# Altium schematic sheet MCU.SchDoc(MCU)
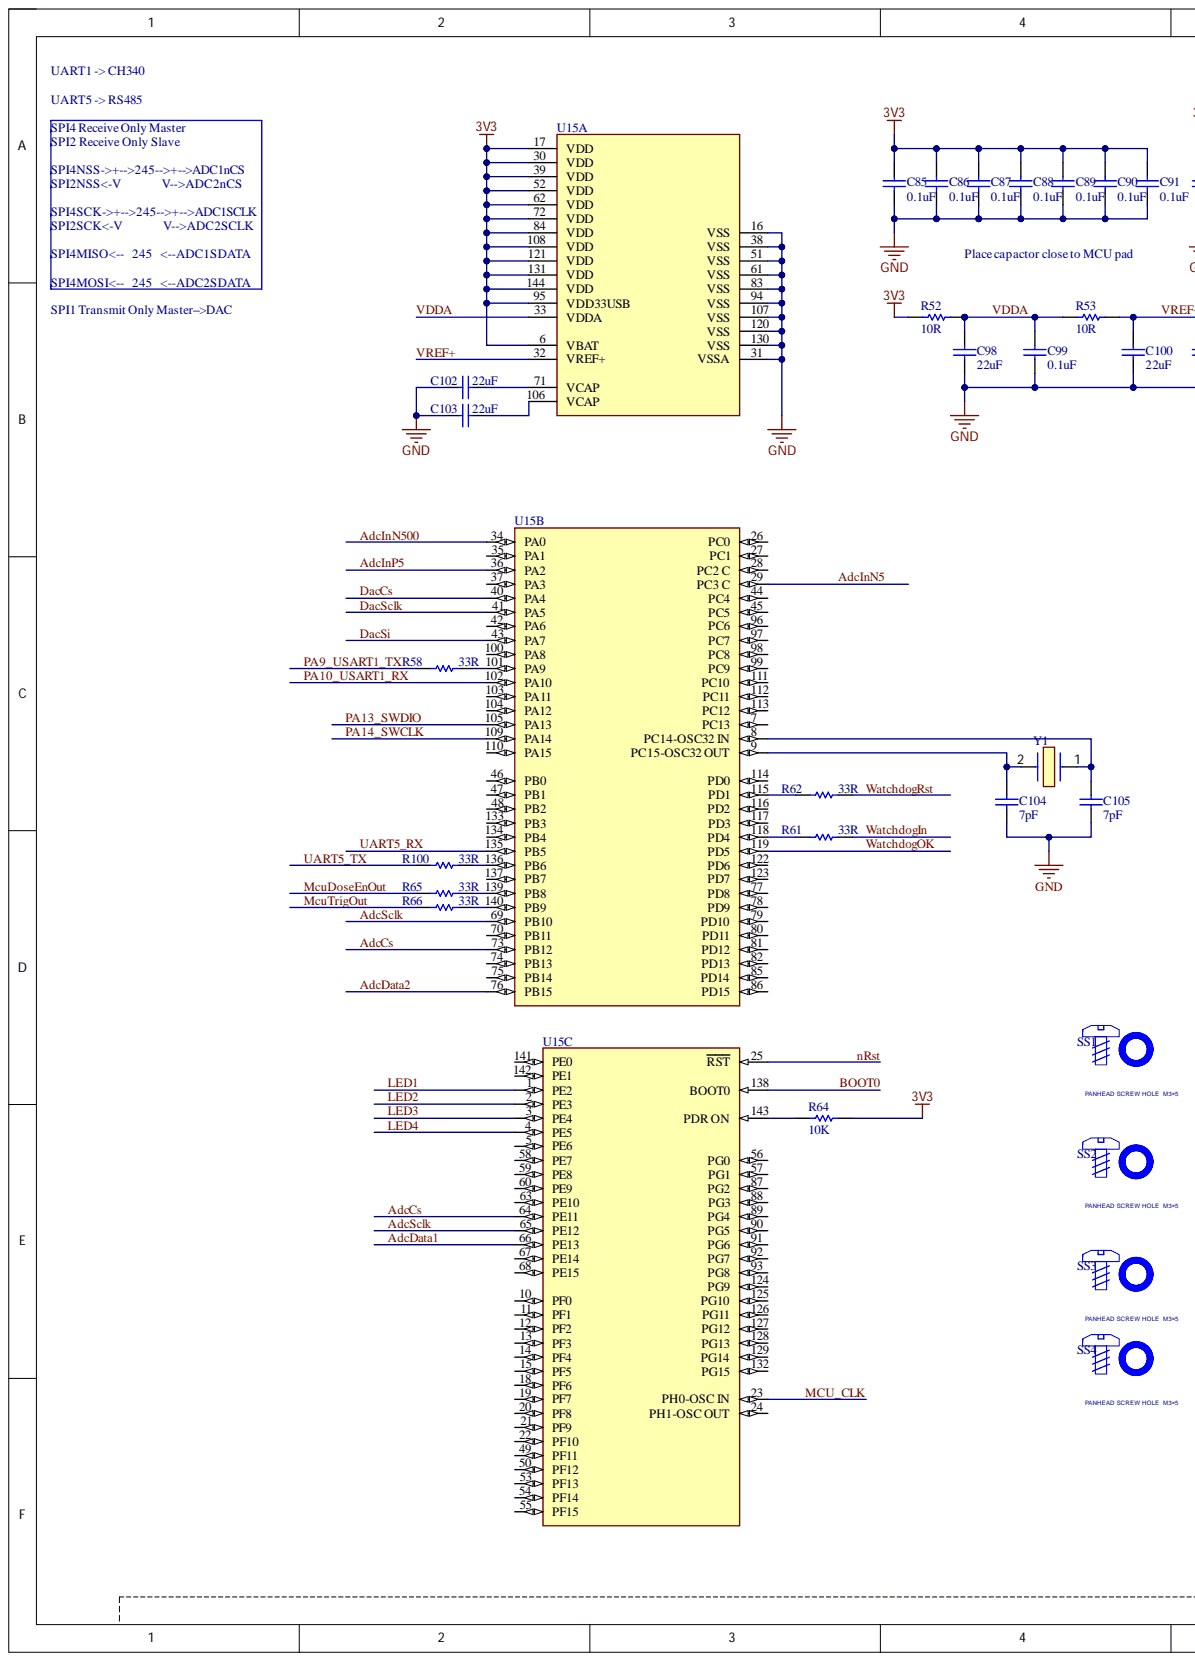
[diagram p0: MCU.SchDoc, left]
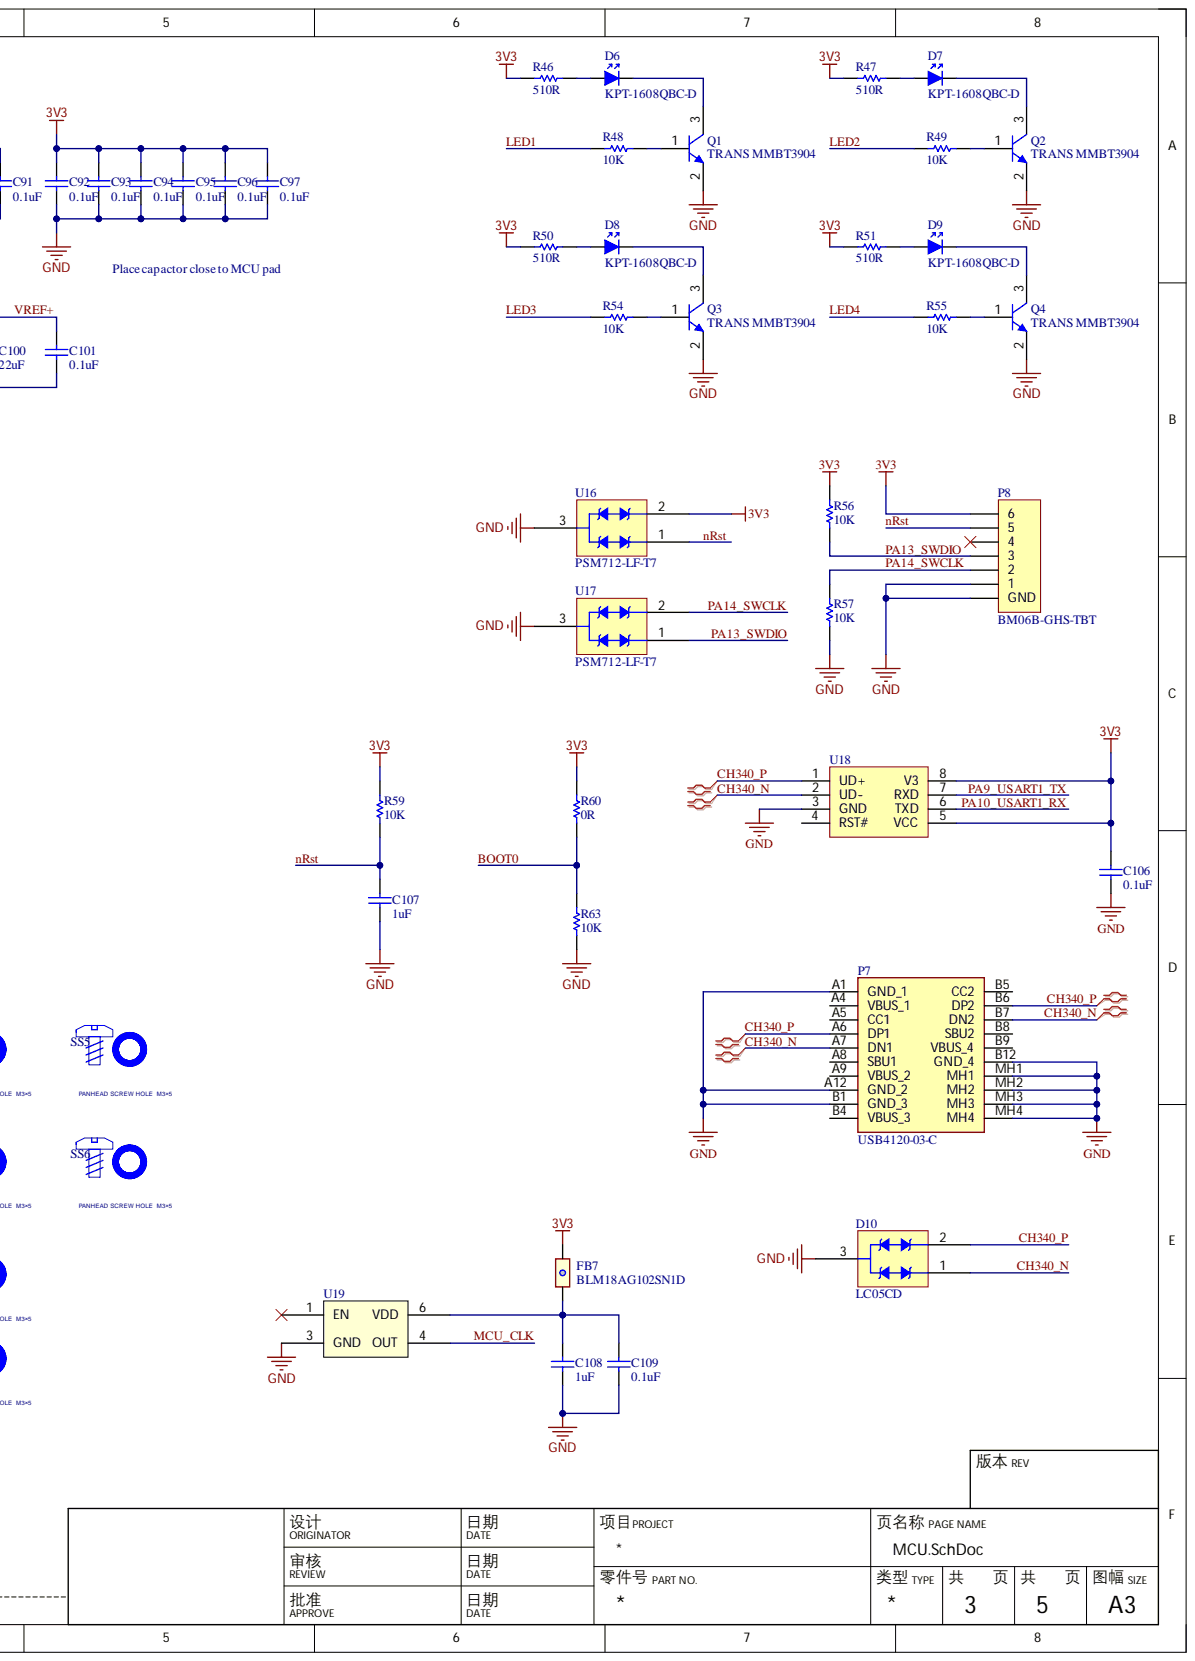
[diagram p1: MCU.SchDoc, right]

## Parts
C85  0.1uF  [C0402(1005)]
C86  0.1uF  [C0402(1005)]
C87  0.1uF  [C0402(1005)]
C88  0.1uF  [C0402(1005)]
C89  0.1uF  [C0402(1005)]
C90  0.1uF  [C0402(1005)]
C91  0.1uF  [C0402(1005)]
C92  0.1uF  [C0402(1005)]
C93  0.1uF  [C0402(1005)]
C94  0.1uF  [C0402(1005)]
C95  0.1uF  [C0402(1005)]
C96  0.1uF  [C0402(1005)]
C97  0.1uF  [C0402(1005)]
C98  22uF  [C0805(2012)]
C99  0.1uF  [C0402(1005)]
C100  22uF  [C0805(2012)]
C101  0.1uF  [C0402(1005)]
C102  22uF  [C0805(2012)]
C103  22uF  [C0805(2012)]
C104  7pF  [C0603(1608)]
C105  7pF  [C0603(1608)]
C106  0.1uF  [C0603(1608)]
C107  1uF  [C0402(1005)]
C108  1uF  [C0402(1005)]
C109  0.1uF  [C0402(1005)]
D6  KPT-1608QBC-D  [KPT-1608QBC-D]
D7  KPT-1608QBC-D  [KPT-1608QBC-D]
D8  KPT-1608QBC-D  [KPT-1608QBC-D]
D9  KPT-1608QBC-D  [KPT-1608QBC-D]
D10  LC05CD  [SOT23-3]
FB7  BLM18AG102SN1D  [FB0603(1608)]
P7  USB4120-03-C  [USB412003C]
P8  BM06B-GHS-TBT  [BM06B-GHS-TBT]
Q1  TRANS MMBT3904  [SOT-23-3]
Q2  TRANS MMBT3904  [SOT-23-3]
Q3  TRANS MMBT3904  [SOT-23-3]
Q4  TRANS MMBT3904  [SOT-23-3]
R46  510R  [R0603(1608)]
R47  510R  [R0603(1608)]
R48  10K  [R0402(1005)]
R49  10K  [R0402(1005)]
R50  510R  [R0603(1608)]
R51  510R  [R0603(1608)]
R52  10R  [R0603(1608)]
R53  10R  [R0603(1608)]
R54  10K  [R0402(1005)]
R55  10K  [R0402(1005)]
R56  10K  [R0402(1005)]
R57  10K  [R0402(1005)]
R58  33R  [R0402(1005)]
R59  10K  [R0402(1005)]
R60  0R  [R0402(1005)]
R61  33R  [R0402(1005)]
R62  33R  [R0402(1005)]
R63  10K  [R0402(1005)]
R64  10K  [R0402(1005)]
R65  33R  [R0402(1005)]
R66  33R  [R0402(1005)]
R100  33R  [R0402(1005)]
SS1  PANHEAD SCREW HOLE  M3×5  [MOUNTING_HOLE_3MM_RND]
SS2  PANHEAD SCREW HOLE  M3×5  [MOUNTING_HOLE_3MM_RND]
SS3  PANHEAD SCREW HOLE  M3×5  [MOUNTING_HOLE_3MM_RND]
SS4  PANHEAD SCREW HOLE  M3×5  [MOUNTING_HOLE_3MM_RND]
SS5  PANHEAD SCREW HOLE  M3×5  [MOUNTING_HOLE_3MM_RND]
SS6  PANHEAD SCREW HOLE  M3×5  [MOUNTING_HOLE_3MM_RND]
U15  (no value)  [LQFP144-1A-IPC_A]  (parts A,B,C)
U16  PSM712-LF-T7  [SOT23-3]
U17  PSM712-LF-T7  [SOT23-3]
U18  (no value)  [SOIC8]
U19  (no value)  [ECS-3225S33-250-FN-TR]
Y1  (no value)  [SC32S7PF20PPM]

## Nets
3V3: C85.2 C86.2 C87.2 C88.2 C89.2 C90.2 C91.2 C92.2 C93.2 C94.2 C95.2 C96.2 C97.2 C106.2 FB7.1 P8.6 R46.1 R47.1 R50.1 R51.1 R52.2 R56.2 R59.2 R60.2 R64.2 U15.6(VBAT) U15.17(VDD) U15.30(VDD) U15.39(VDD) U15.52(VDD) U15.62(VDD) U15.72(VDD) U15.84(VDD) U15.95(VDD33USB) U15.108(VDD) U15.121(VDD) U15.131(VDD) U15.144(VDD) U16.2 U18.5(VCC) U18.8(V3)
GND: C85.1 C86.1 C87.1 C88.1 C89.1 C90.1 C91.1 C92.1 C93.1 C94.1 C95.1 C96.1 C97.1 C98.1 C99.1 C100.1 C101.1 C102.2 C103.2 C104.1 C105.1 C106.1 C107.1 C108.1 C109.1 D10.3 P7.A1(GND_1) P7.A12(GND_2) P7.B1(GND_3) P7.B12(GND_4) P7.MH1 P7.MH2 P7.MH3 P7.MH4 P8.1 P8.GND Q1.2 Q2.2 Q3.2 Q4.2 R57.1 R63.1 U15.16(VSS) U15.31(VSSA) U15.38(VSS) U15.51(VSS) U15.61(VSS) U15.83(VSS) U15.94(VSS) U15.107(VSS) U15.120(VSS) U15.130(VSS) U16.3 U17.3 U18.3(GND) U19.3(GND)
AdcCs: U15.64(PE11) U15.73(PB12)
AdcData1: U15.66(PE13)
AdcData2: U15.76(PB15)
AdcInN5: U15.29(PC3_C)
AdcInN500: U15.34(PA0)
AdcInP5: U15.36(PA2)
AdcSclk: U15.65(PE12) U15.69(PB10)
BOOT0: R60.1 R63.2 U15.138(BOOT0)
CH340_N: D10.1 P7.A7(DN1) P7.B7(DN2) U18.2(UD-)
CH340_P: D10.2 P7.A6(DP1) P7.B6(DP2) U18.1(UD+)
DacCs: U15.40(PA4)
DacSclk: U15.41(PA5)
DacSi: U15.43(PA7)
LED1: R48.2 U15.1(PE2)
LED2: R49.2 U15.2(PE3)
LED3: R54.2 U15.3(PE4)
LED4: R55.2 U15.4(PE5)
MCU_CLK: U15.23(PH0-OSC_IN) U19.4(OUT)
McuDoseEnOut: R65.1
McuTrigOut: R66.1
nRst: C107.2 P8.5 R59.1 U15.25(RST) U16.1
PA9_USART1_TX: R58.1 U18.7(RXD)
PA10_USART1_RX: U15.102(PA10) U18.6(TXD)
PA13_SWDIO: P8.3 R56.1 U15.105(PA13) U17.1
PA14_SWCLK: P8.2 R57.2 U15.109(PA14) U17.2
UART5_RX: U15.135(PB5)
UART5_TX: R100.1
VDDA: C98.2 C99.2 R52.1 R53.2 U15.33(VDDA)
VREF+: C100.2 C101.2 R53.1 U15.32(VREF+)
WatchdogIn: R61.2
WatchdogOK: U15.119(PD5)
WatchdogRst: R62.2
NetC102_1: C102.1 U15.71(VCAP)
NetC103_1: C103.1 U15.106(VCAP)
NetC104_2: C104.2 U15.9(PC15-OSC32_OUT) Y1.2
NetC105_2: C105.2 U15.8(PC14-OSC32_IN) Y1.1
NetC108_2: C108.2 C109.2 FB7.2 U19.6(VDD)
NetD6_1: D6.1 R46.2
NetD6_2: D6.2 Q1.3
NetD7_1: D7.1 R47.2
NetD7_2: D7.2 Q2.3
NetD8_1: D8.1 R50.2
NetD8_2: D8.2 Q3.3
NetD9_1: D9.1 R51.2
NetD9_2: D9.2 Q4.3
NetQ1_1: Q1.1 R48.1
NetQ2_1: Q2.1 R49.1
NetQ3_1: Q3.1 R54.1
NetQ4_1: Q4.1 R55.1
NetR58_2: R58.2 U15.101(PA9)
NetR61_1: R61.1 U15.118(PD4)
NetR62_1: R62.1 U15.115(PD1)
NetR64_1: R64.1 U15.143(PDR_ON)
NetR65_2: R65.2 U15.139(PB8)
NetR66_2: R66.2 U15.140(PB9)
NetR100_2: R100.2 U15.136(PB6)

Not connected: P7 A4(VBUS_1) A5(CC1) A8(SBU1) A9(VBUS_2) B4(VBUS_3) B5(CC2) B8(SBU2) B9(VBUS_4); P8 4; U15 5(PE6) 7(PC13) 10(PF0) 11(PF1) 12(PF2) 13(PF3) 14(PF4) 15(PF5) 18(PF6) 19(PF7) 20(PF8) 21(PF9) 22(PF10) 24(PH1-OSC_OUT) 26(PC0) 27(PC1) 28(PC2_C) 35(PA1) 37(PA3) 42(PA6) 44(PC4) 45(PC5) 46(PB0) 47(PB1) 48(PB2) 49(PF11) 50(PF12) 53(PF13) 54(PF14) 55(PF15) 56(PG0) 57(PG1) 58(PE7) 59(PE8) 60(PE9) 63(PE10) 67(PE14) 68(PE15) 70(PB11) 74(PB13) 75(PB14) 77(PD8) 78(PD9) 79(PD10) 80(PD11) 81(PD12) 82(PD13) 85(PD14) 86(PD15) 87(PG2) 88(PG3) 89(PG4) 90(PG5) 91(PG6) 92(PG7) 93(PG8) 96(PC6) 97(PC7) 98(PC8) 99(PC9) 100(PA8) 103(PA11) 104(PA12) 110(PA15) 111(PC10) 112(PC11) 113(PC12) 114(PD0) 116(PD2) 117(PD3) 122(PD6) 123(PD7) 124(PG9) 125(PG10) 126(PG11) 127(PG12) 128(PG13) 129(PG14) 132(PG15) 133(PB3) 134(PB4) 137(PB7) 141(PE0) 142(PE1); U18 4(RST#); U19 1(EN)

## Library data of the parts
C85-C97 C99 C101 = Cap 0.1uF 0402-50V: ceramic 50V; 价格: ¥0.375@100; 制造商: TDK; 型号: C1005X7R1H104K050BB; Component Kind: Standard; Library Name: RCL_release.SchLib; 供应商及库存编号: e络盟 2525047
C98 C100 C102 C103 = Cap 22uF 0805-6.3V: ceramic 6.3V; 价格: ￥1.759 @ 1; 制造商: KEMET; 型号: C0805C226M9PACTU; Component Kind: Standard; Library Name: RCL_release.SchLib; 供应商及库存编号: iCKey ET-1108326
C104 C105 = Cap 7pF 0603-50V C0G: ceramic 50V C0G; 制造商: muRata; 型号: GRM1885C1H7R0CA01D; Component Kind: Standard; Library Name: RCL_release.SchLib
C106 = Cap 0.1uF 0603-10V: ceramic 10V; 价格: ¥0.092@100; 制造商: MULTICOMP; 型号: MC0603B104K100CT; Component Kind: Standard; Library Name: RCL_release.SchLib; 供应商及库存编号: e络盟 2627427
C107 C108 = Cap 1uF 0402-10V: ceramic 10V; 价格: ¥0.19@100; 制造商: KEMET; 型号: C0402C105K8PACTU; Component Kind: Standard; Library Name: RCL_release.SchLib; 供应商及库存编号: e络盟 1793827
C109 = Cap 0.1uF 0402-25V: ceramic 25V; 价格: ¥0.33@100; 制造商: KEMET; 型号: C0402C104M3RACTU; Component Kind: Standard; Library Name: RCL_release.SchLib; 供应商及库存编号: e络盟 2528342
D6-D9 = LED KPT-1608QBC-D: LED, Blue， 0603; 价格: ￥1.13@10; 制造商: Kingbright; Component Kind: Standard; Library Name: Transistor_release.SchLib; 供应商及库存编号: e络盟 2217978; 型号: KPT-1608QBC-D
D10 = LC05CD: TVS FOR USB2.0; Component Kind: Standard; Library Name: Transistor_release.SchLib; Package: SOT-23(SOT-23-3); Supplier: LC; SuppliersPartNumber: C32677
FB7 = FB-1K@100MHz 0603: FERRITE BEAD 1 KOHM 0603 1LN; 价格: ¥0.25@100; 制造商: MURATA; 名称: 磁珠; Component Kind: Standard; Library Name: RCL_release.SchLib; 供应商及库存编号: e络盟 1515671; 型号: BLM18AG102SN1D
P7 = USB4120-03-C: USB Connectors USB C Rec 3u" vert 16P SMT & TH stakes pegs T+R+C H = 9.25; Component Kind: Standard; Library Name: Connector_release.SchLib
Q1-Q4 = MMBT3904: NPN 40V 0.2A SOT23; 价格: ￥0.5184@100; 制造商: Diodes; 型号: MMBT3904-7-F; Component Kind: Standard; Library Name: Transistor_release.SchLib; 供应商及库存编号: digikey MMBT3904-FDICT-ND
R46 R47 R50 R51 = Res 510R 0603: Res ±1%; Component Kind: Standard; Library Name: RCL_release.SchLib
R48 R49 R54-R57 R59 R63 R64 = Res 10K 0402: Res ±1%; Component Kind: Standard; Library Name: RCL_release.SchLib
R52 R53 = Res 10R 0603: Res ±1%; Component Kind: Standard; Library Name: RCL_release.SchLib
R58 R61 R62 R65 R66 R100 = Res 33R 0402: Res ±1%; Component Kind: Standard; Library Name: RCL_release.SchLib
R60 = Res 0R 0402: Res ±1%; Component Kind: Standard; Library Name: RCL_release.SchLib
SS1-SS6 = Screw Standoff hole_3mm: Grounding the Mounting holes  3mm; Mfr_Name: Building Fasteners; Mfr_Part_Number: PMSSS 440 0038 PH; Note: SCREW FOR STANDOFF; Component Kind: Standard; Library Name: Connector_release.SchLib
U15 = STM32H723ZGT6: IC MCU 32BIT 1MB FLASH 144LQFP; Component Kind: Standard; Library Name: IC_release.SchLib
U16 U17 = PSM712-LF-T7: TVS; Component Kind: Standard; ComponentLink1Description: 供应商链接; ComponentLink1URL: http://www.szlcsc.com/product/details_33642.html; Library Name: Transistor_release.SchLib; Package: SOT-23(SOT-23-3); Supplier: LC; SuppliersPartNumber: C32677
U18 = CH340N: USB转TTL串口; Component Kind: Standard; Library Name: IC_release.SchLib
U19 = ECS-3225S33-250-FN-TR: OSC XO 25.0000MHZ HCMOS SMD; 价格: $0.755@1K; 制造商: ECS Inc.; Component Kind: Standard; Library Name: IC_release.SchLib; 供应商及库存编号: digikey XC2221TR-ND; 型号: ECS-3225S33-250-FN-TR
Y1 = XTAL32.768KHz: SC32S-7PF20PPM; Component Kind: Standard; Library Name: IC_release.SchLib
P8 = BM06B-GHS-TBT: Component Kind: Standard; Description: BM06B-GHS-TBT; Library Name: Connector2023.SchLib
